# Altium schematic sheet BlockSheet.SchDoc
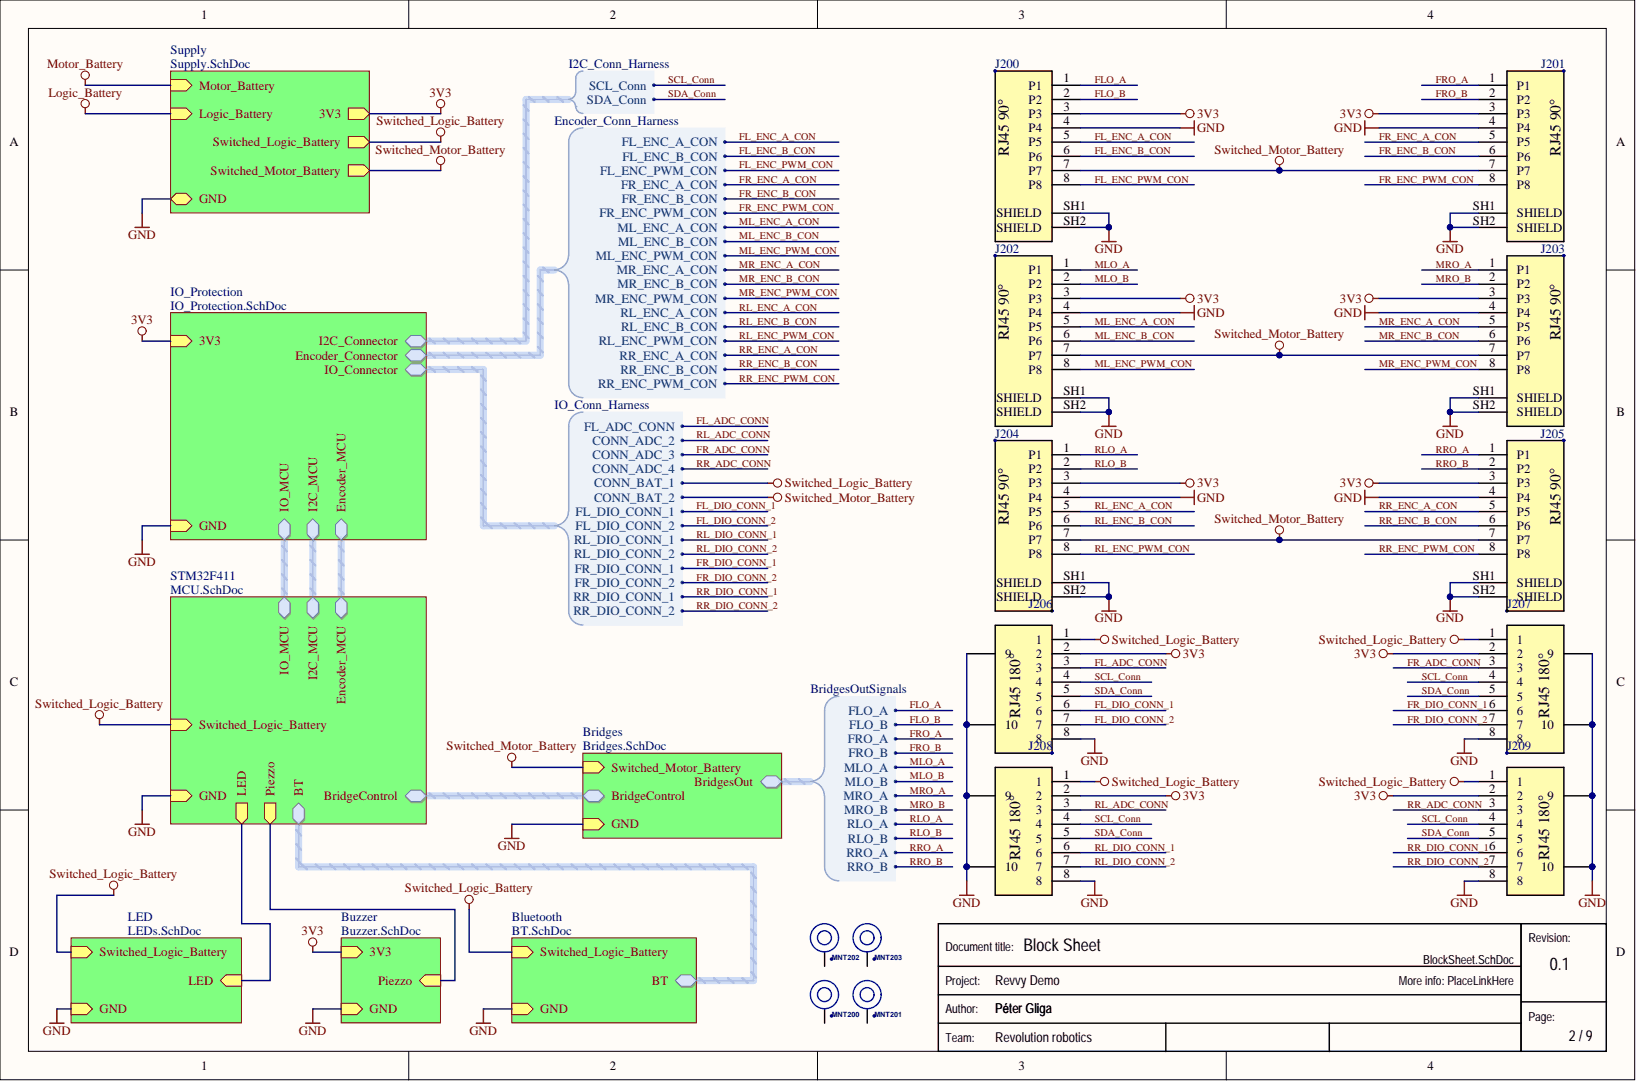
[diagram p0: BlockSheet.SchDoc]

## Parts
J200  6339160-1  [TE_6339160-1_6339160-1(Primary)]  (value not drawn)
J201  6339160-1  [TE_6339160-1_6339160-1(Primary)]  (value not drawn)
J202  6339160-1  [TE_6339160-1_6339160-1(Primary)]  (value not drawn)
J203  6339160-1  [TE_6339160-1_6339160-1(Primary)]  (value not drawn)
J204  6339160-1  [TE_6339160-1_6339160-1(Primary)]  (value not drawn)
J205  6339160-1  [TE_6339160-1_6339160-1(Primary)]  (value not drawn)
J206  1-338088-3  [TE_1-338088-3]  (value not drawn)
J207  1-338088-3  [TE_1-338088-3]  (value not drawn)
J208  1-338088-3  [TE_1-338088-3]  (value not drawn)
J209  1-338088-3  [TE_1-338088-3]  (value not drawn)
MNT200  !Value is missing!  [MNT-HOLE-M3]  (value not drawn)  (no BOM)
MNT201  !Value is missing!  [MNT-HOLE-M3]  (value not drawn)  (no BOM)
MNT202  !Value is missing!  [MNT-HOLE-M3]  (value not drawn)  (no BOM)
MNT203  !Value is missing!  [MNT-HOLE-M3]  (value not drawn)  (no BOM)

## Nets
3V3: J200.3(P3) J201.3(P3) J202.3(P3) J203.3(P3) J204.3(P3) J205.3(P3) J206.2 J207.2 J208.2 J209.2
GND: J200.4(P4) J200.SH1(SHIELD) J200.SH2(SHIELD) J201.4(P4) J201.SH1(SHIELD) J201.SH2(SHIELD) J202.4(P4) J202.SH1(SHIELD) J202.SH2(SHIELD) J203.4(P4) J203.SH1(SHIELD) J203.SH2(SHIELD) J204.4(P4) J204.SH1(SHIELD) J204.SH2(SHIELD) J205.4(P4) J205.SH1(SHIELD) J205.SH2(SHIELD) J206.8 J206.P1 J206.P2 J207.8 J207.P1 J207.P2 J208.8 J208.P1 J208.P2 J209.8 J209.P1 J209.P2
Switched_Logic_Battery: J206.1 J207.1 J208.1 J209.1
Switched_Motor_Battery: J200.7(P7) J201.7(P7) J202.7(P7) J203.7(P7) J204.7(P7) J205.7(P7)
FL_ADC_CONN: J206.3
FL_DIO_CONN_1: J206.6
FL_DIO_CONN_2: J206.7
FL_ENC_A_CON: J200.5(P5)
FL_ENC_B_CON: J200.6(P6)
FL_ENC_PWM_CON: J200.8(P8)
FLO_A: J200.1(P1)
FLO_B: J200.2(P2)
FR_ADC_CONN: J207.3
FR_DIO_CONN_1: J207.6
FR_DIO_CONN_2: J207.7
FR_ENC_A_CON: J201.5(P5)
FR_ENC_B_CON: J201.6(P6)
FR_ENC_PWM_CON: J201.8(P8)
FRO_A: J201.1(P1)
FRO_B: J201.2(P2)
ML_ENC_A_CON: J202.5(P5)
ML_ENC_B_CON: J202.6(P6)
ML_ENC_PWM_CON: J202.8(P8)
MLO_A: J202.1(P1)
MLO_B: J202.2(P2)
MR_ENC_A_CON: J203.5(P5)
MR_ENC_B_CON: J203.6(P6)
MR_ENC_PWM_CON: J203.8(P8)
MRO_A: J203.1(P1)
MRO_B: J203.2(P2)
RL_ADC_CONN: J208.3
RL_DIO_CONN_1: J208.6
RL_DIO_CONN_2: J208.7
RL_ENC_A_CON: J204.5(P5)
RL_ENC_B_CON: J204.6(P6)
RL_ENC_PWM_CON: J204.8(P8)
RLO_A: J204.1(P1)
RLO_B: J204.2(P2)
RR_ADC_CONN: J209.3
RR_DIO_CONN_1: J209.6
RR_DIO_CONN_2: J209.7
RR_ENC_A_CON: J205.5(P5)
RR_ENC_B_CON: J205.6(P6)
RR_ENC_PWM_CON: J205.8(P8)
RRO_A: J205.1(P1)
RRO_B: J205.2(P2)
SCL_Conn: J206.4 J207.4 J208.4 J209.4
SDA_Conn: J206.5 J207.5 J208.5 J209.5
NetMNT200_1: MNT200.1
NetMNT201_1: MNT201.1
NetMNT202_1: MNT202.1
NetMNT203_1: MNT203.1
BridgeControl.FL_INA:
BridgeControl.FL_INB:
BridgeControl.FL_PWM:
BridgeControl.FR_INA:
BridgeControl.FR_INB:
BridgeControl.FR_PWM:
BridgeControl.ML_INA:
BridgeControl.ML_INB:
BridgeControl.ML_PWM:
BridgeControl.MR_INA:
BridgeControl.MR_INB:
BridgeControl.MR_PWM:
BridgeControl.RL_INA:
BridgeControl.RL_INB:
BridgeControl.RL_PWM:
BridgeControl.RR_INA:
BridgeControl.RR_INB:
BridgeControl.RR_PWM:
BT.BT_EN:
BT.BT_RX:
BT.BT_Stat:
BT.BT_TX:
Encoder_MCU.FL_ENC_A_MCU:
Encoder_MCU.FL_ENC_B_MCU:
Encoder_MCU.FL_ENC_PWM_MCU:
Encoder_MCU.FR_ENC_A_MCU:
Encoder_MCU.FR_ENC_B_MCU:
Encoder_MCU.FR_ENC_PWM_MCU:
Encoder_MCU.ML_ENC_A_MCU:
Encoder_MCU.ML_ENC_B_MCU:
Encoder_MCU.ML_ENC_PWM_MCU:
Encoder_MCU.MR_ENC_A_MCU:
Encoder_MCU.MR_ENC_B_MCU:
Encoder_MCU.MR_ENC_PWM_MCU:
Encoder_MCU.RL_ENC_A_MCU:
Encoder_MCU.RL_ENC_B_MCU:
Encoder_MCU.RL_ENC_PWM_MCU:
Encoder_MCU.RR_ENC_A_MCU:
Encoder_MCU.RR_ENC_B_MCU:
Encoder_MCU.RR_ENC_PWM_MCU:
I2C_MCU.SCL_MCU:
I2C_MCU.SDA_MCU:
IO_MCU.MCU_ADC_1:
IO_MCU.MCU_ADC_2:
IO_MCU.MCU_ADC_3:
IO_MCU.MCU_ADC_4:
IO_MCU.MCU_BAT_1:
IO_MCU.MCU_BAT_2:
IO_MCU.MCU_DIO_1:
IO_MCU.MCU_DIO_2:
IO_MCU.MCU_DIO_3:
IO_MCU.MCU_DIO_4:
IO_MCU.MCU_DIO_5:
IO_MCU.MCU_DIO_6:
IO_MCU.MCU_DIO_7:
IO_MCU.MCU_DIO_8:
LED:
Logic_Battery:
Motor_Battery:
Piezzo:

## Library data of the parts
J200-J205 = 6339160-1: MJ,RJ45,SMT,SHLD,PANEL GRD; Centerline_Pitch: 1.02 mm[.04 in]; MF: TE Connectivity; Number_of_Positions: 8; Component Kind: Standard; Digi-Key_Part_Number: A101755CT-ND; Digi-Key_Purchase_URL: https://www.digikey.hu/product-detail/en/te-connectivity-amp-connectors/6339160-1/A101755CT-ND/2677510?utm_source=snapeda&utm_medium=aggregator&utm_campaign=symbol; EU_RoHS_Compliance: Compliant; Link: http://www.te.com/usa-en/product-6339160-1.html; MP: 6339160-1; Package: None; SnapEDA_Link: https://www.snapeda.com/parts/6339160-1/TE%20Connectivity%20AMP%20Connectors/view-part/?ref=snap; Library Name: RevvyDemo.SchLib
J206-J209 = 1-338088-3: 8/8 INV.MOD.JACK; Centerline_Pitch: 1.02 mm[.04 in]; MF: TE Connectivity; Number_of_Positions: 8; Component Kind: Standard; Digi-Key_Part_Number: A99306CT-ND; Digi-Key_Purchase_URL: https://www.digikey.hu/product-detail/en/te-connectivity-amp-connectors/1-338088-3/A99306CT-ND/1920155?utm_source=snapeda&utm_medium=aggregator&utm_campaign=symbol; EU_RoHS_Compliance: Compliant; Link: http://www.te.com/usa-en/product-1-338088-3.html; MP: 1-338088-3; Package: None; SnapEDA_Link: https://www.snapeda.com/parts/1-338088-3/TE%20Connectivity%20AMP%20Connectors/view-part/?ref=snap; Library Name: RevvyDemo.SchLib
MNT200-MNT203 = Mounting hole: Parameter: !Param is missing!; Description: Mounting hole; Value: !Value is missing!; Library Name: RevvyDemo.SchLib
